# Altium schematic sheet P05_MPU4.SchDoc(P05_MPU4)
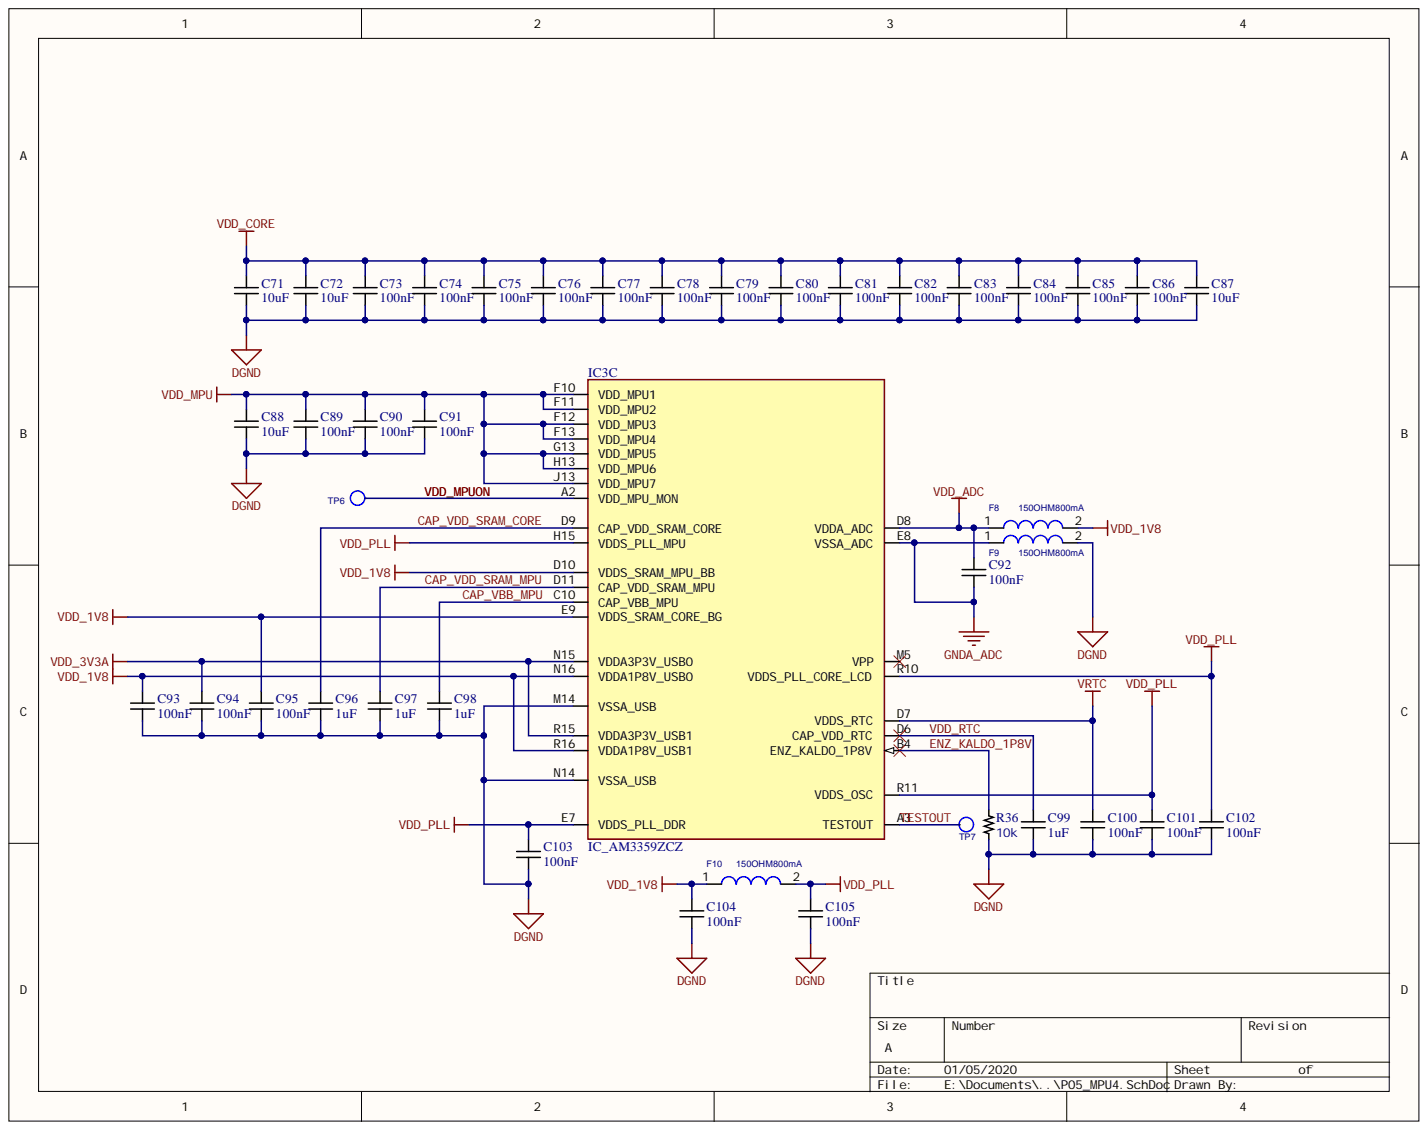
[diagram p0: P05_MPU4.SchDoc]

## Parts
C71  Capacitance: 10uF  [CAP0805]  (hidden comment: C2012X6S1A106K085AC)
C72  Capacitance: 10uF  [CAP0805]  (hidden comment: C2012X6S1A106K085AC)
C73  Capacitance: 100nF  [CAP0402]  (hidden comment: C0402C104K4RACTU)
C74  Capacitance: 100nF  [CAP0402]  (hidden comment: C0402C104K4RACTU)
C75  Capacitance: 100nF  [CAP0402]  (hidden comment: C0402C104K4RACTU)
C76  Capacitance: 100nF  [CAP0402]  (hidden comment: C0402C104K4RACTU)
C77  Capacitance: 100nF  [CAP0402]  (hidden comment: C0402C104K4RACTU)
C78  Capacitance: 100nF  [CAP0402]  (hidden comment: C0402C104K4RACTU)
C79  Capacitance: 100nF  [CAP0402]  (hidden comment: C0402C104K4RACTU)
C80  Capacitance: 100nF  [CAP0402]  (hidden comment: C0402C104K4RACTU)
C81  Capacitance: 100nF  [CAP0402]  (hidden comment: C0402C104K4RACTU)
C82  Capacitance: 100nF  [CAP0402]  (hidden comment: C0402C104K4RACTU)
C83  Capacitance: 100nF  [CAP0402]  (hidden comment: C0402C104K4RACTU)
C84  Capacitance: 100nF  [CAP0402]  (hidden comment: C0402C104K4RACTU)
C85  Capacitance: 100nF  [CAP0402]  (hidden comment: C0402C104K4RACTU)
C86  Capacitance: 100nF  [CAP0402]  (hidden comment: C0402C104K4RACTU)
C87  Capacitance: 10uF  [CAP0805]  (hidden comment: C2012X6S1A106K085AC)
C88  Capacitance: 10uF  [CAP0805]  (hidden comment: C2012X6S1A106K085AC)
C89  Capacitance: 100nF  [CAP0402]  (hidden comment: C0402C104K4RACTU)
C90  Capacitance: 100nF  [CAP0402]  (hidden comment: C0402C104K4RACTU)
C91  Capacitance: 100nF  [CAP0402]  (hidden comment: C0402C104K4RACTU)
C92  Capacitance: 100nF  [CAP0402]  (hidden comment: C0402C104K4RACTU)
C93  Capacitance: 100nF  [CAP0402]  (hidden comment: C0402C104K4RACTU)
C94  Capacitance: 100nF  [CAP0402]  (hidden comment: C0402C104K4RACTU)
C95  Capacitance: 100nF  [CAP0402]  (hidden comment: C0402C104K4RACTU)
C96  Capacitance: 1uF  [CAP0402]  (hidden comment: CC0402KRX5R6BB105)
C97  Capacitance: 1uF  [CAP0402]  (hidden comment: CC0402KRX5R6BB105)
C98  Capacitance: 1uF  [CAP0402]  (hidden comment: CC0402KRX5R6BB105)
C99  Capacitance: 1uF  [CAP0402]  (hidden comment: CC0402KRX5R6BB105)
C100  Capacitance: 100nF  [CAP0402]  (hidden comment: C0402C104K4RACTU)
C101  Capacitance: 100nF  [CAP0402]  (hidden comment: C0402C104K4RACTU)
C102  Capacitance: 100nF  [CAP0402]  (hidden comment: C0402C104K4RACTU)
C103  Capacitance: 100nF  [CAP0402]  (hidden comment: C0402C104K4RACTU)
C104  Capacitance: 100nF  [CAP0402]  (hidden comment: C0402C104K4RACTU)
C105  Capacitance: 100nF  [CAP0402]  (hidden comment: C0402C104K4RACTU)
F8  150OHM800mA  [FB0805]
F9  150OHM800mA  [FB0805]
F10  150OHM800mA  [FB0805]
IC3  IC_AM3359ZCZ  [BGA-324]  (part C)
R36  Resistance: 10k  [RES0402]  (hidden comment: RC0402FR-0710KL)
TP6  TESTPT1  [tp1-8040]  (value not drawn)  (no BOM)
TP7  TESTPT1  [tp1-8040]  (value not drawn)  (no BOM)

## Nets
DGND: C71.1 C72.1 C73.2 C74.2 C75.2 C76.2 C77.2 C78.2 C79.2 C80.2 C81.2 C82.2 C83.2 C84.2 C85.2 C86.2 C87.1 C88.1 C89.2 C90.2 C91.2 C93.2 C94.2 C95.2 C96.2 C97.2 C98.2 C99.2 C100.2 C101.2 C102.2 C103.2 C104.2 C105.2 F9.2 IC3.M14(VSSA_USB) IC3.N14(VSSA_USB) R36.2
GNDA_ADC: C92.2 F9.1 IC3.E8(VSSA_ADC)
VDD_1V8: C93.1 C95.1 C104.1 F8.2 F10.1 IC3.D10(VDDS_SRAM_MPU_BB) IC3.E9(VDDS_SRAM_CORE_BG) IC3.N16(VDDA1P8V_USB0) IC3.R16(VDDA1P8V_USB1)
VDD_3V3A: C94.1 IC3.N15(VDDA3P3V_USB0) IC3.R15(VDDA3P3V_USB1)
VDD_ADC: C92.1 F8.1 IC3.D8(VDDA_ADC)
VDD_CORE: C71.2 C72.2 C73.1 C74.1 C75.1 C76.1 C77.1 C78.1 C79.1 C80.1 C81.1 C82.1 C83.1 C84.1 C85.1 C86.1 C87.2
VDD_MPU: C88.2 C89.1 C90.1 C91.1 IC3.F10(VDD_MPU1) IC3.F11(VDD_MPU2) IC3.F12(VDD_MPU3) IC3.F13(VDD_MPU4) IC3.G13(VDD_MPU5) IC3.H13(VDD_MPU6) IC3.J13(VDD_MPU7)
VDD_PLL: C101.1 C102.1 C103.1 C105.1 F10.2 IC3.E7(VDDS_PLL_DDR) IC3.H15(VDDS_PLL_MPU) IC3.R10(VDDS_PLL_CORE_LCD) IC3.R11(VDDS_OSC)
VRTC: C100.1 IC3.D7(VDDS_RTC)
CAP_VBB_MPU: C98.1 IC3.C10(CAP_VBB_MPU)
CAP_VDD_SRAM_CORE: C96.1 IC3.D9(CAP_VDD_SRAM_CORE)
CAP_VDD_SRAM_MPU: C97.1 IC3.D11(CAP_VDD_SRAM_MPU)
ENZ_KALDO_1P8V: IC3.B4(ENZ_KALDO_1P8V) R36.1
TESTOUT: IC3.A3(TESTOUT) TP7.1
VDD_MPUON: IC3.A2(VDD_MPU_MON) TP6.1
VDD_RTC: C99.1 IC3.D6(CAP_VDD_RTC)

Not connected: IC3 M5(VPP)

## Library data of the parts
C71 C72 C87 C88 = CAP_10uF: MLCC 10uF 0805 SMD; Manufacturer: TDK; Voltage Rating (DC): 10V; Tolerance: 10%; Dielectric Material: Ceramic; Dielectric: X6S; Width: 0.049inch; Weight: 0.000194oz; Voltage Rating: 10V; Thickness: 0.037inch; Termination: SMD/SMT; Supplier Part Number 1: 445-7613-1-ND; Supplier 1: Digi-Key; Stock 1: 46852; RoHS: Yes; REACH SVHC: No SVHC; Pricing 1: 1=0.30000, 10=0.20500, 100=0.11610, 500=0.08198, 1000=0.07173 (USD); Packaging: Tape and Reel; Package Quantity: 4000; Mount: Surface Mount; Min Operating Temperature: -55°C; Max Operating Temperature: 105°C; Manufacturer Part Number: C2012X6S1A106K085AC; Length: 2mm; Lead Free: Lead Free; Height: 1.25mm; Depth: 1.25mm; Composition: Ceramic; ComponentLink3URL: https://datasheet.ciiva.com/6290/tdkmlcccaprange-6290501.pdf?src-supplier=Digi-Key; ComponentLink3Description: Datasheet; Component Kind: Standard; Case Code (Metric): 2012; Case Code (Imperial): 0805; Case/Package: 0805; Library Name: Orient.SchLib
C73-C86 C89-C95 C100-C105 = CAP_100nF: MLCC 100nF 0402 SMD; Manufacturer: KEMET; Voltage Rating (DC): 16V; Tolerance: 10%; Dissipation Factor: 3.5%; Dielectric: X7R; Width: 0.5mm; Voltage Rating: 16V; Termination: SMD/SMT; Supplier Part Number 1: 399-3521-1-ND; Supplier 1: Digi-Key; Stock 1: 6512243; RoHS: Yes; Radiation Hardening: No; Pricing 1: 1=0.10000, 10=0.04200, 100=0.01860, 500=0.01328, 1000=0.01044, 2500=0.00949, 5000=0.00873 (USD); Packaging: Tape and Reel; Package Quantity: 10000; Mount: Surface Mount; Min Operating Temperature: -55°C; Max Operating Temperature: 125°C; Manufacturer Part Number: C0402C104K4RACTU; Length: 1mm; Height: 0.5mm; Depth: 0.5mm; Contact Plating: Tin,Matte; ComponentLink2URL: https://datasheet.ciiva.com/18192/getdatasheetpartid-385384-18192412.pdf?src-supplier=Verical; ComponentLink2Description: Datasheet; Component Kind: Standard; Case Code (Metric): 1005; Case Code (Imperial): 0402; Case/Package: 0402; Library Name: Orient.SchLib
C96-C99 = CAP_1uF: MLCC 1uF 0402 SMD; Manufacturer: Yageo; Voltage Rating (DC): 10V; Tolerance: 10%; Dissipation Factor: 15%; Dielectric: X5R; Width: 0.02inch; Voltage Rating: 10V; Thickness: 0.022inch; Termination: SMD/SMT; Supplier 1: Digi-Key; Stock 1: 6411796; RoHS: Yes; REACH SVHC: No SVHC; Radiation Hardening: No; Pricing 1: 1=0.10000, 10=0.06300, 100=0.02790, 500=0.01996, 1000=0.01568, 2500=0.01425, 5000=0.01311 (USD); Packaging: Tape and Reel; Package Quantity: 1; Number of Pins: 2; Mount: Surface Mount; Min Operating Temperature: -55°C; Max Operating Temperature: 85°C; Manufacturer Part Number: CC0402KRX5R6BB105; Length: 1mm; Lead Free: Lead Free; Height: 0.5mm; Halogen Free: Halogen Free; Depth: 0.5mm; Composition: Ceramic; ComponentLink3URL: https://datasheet.ciiva.com/176/mlccorderingcode-176705.pdf?src-supplier=Digi-Key; ComponentLink3Description: https://datasheet.ciiva.com/176/mlccorderingcode-176705.pdf?src-supplier=Digi-Key; ComponentLink2URL: https://datasheet.ciiva.com/176/mlccorderingcode-176706.pdf?src-supplier=Digi-Key; ComponentLink2Description: https://datasheet.ciiva.com/176/mlccorderingcode-176706.pdf?src-supplier=Digi-Key; ComponentLink1URL: https://datasheet.ciiva.com/176/upy-gphc-x5r-4v-to-50v-12-176704.pdf?src-supplier=Digi-Key; ComponentLink1Description: https://datasheet.ciiva.com/176/upy-gphc-x5r-4v-to-50v-12-176704.pdf?src-supplier=Digi-Key; Component Kind: Standard; Case Code (Metric): 1005; Case Code (Imperial): 0402; Case/Package: 0402; Library Name: Orient.SchLib
F8-F10 = FERR: FERRITE 800MA 150 OHM 0805 SMD; TIIntPartnumber: 1L0010; PartName: FerriteBead150ohm800mA; MfrNum: LI0805H151R-10; Mfr: Steward; Manufacturer: Laird-Signal Integrity Products; Vendor: Digikey; Impedance @ Frequency: 150 Ohm @ 100MHz; Filter Type: Differential Mode - Single; DC Resistance (DCR): 150 mOhm Max; Supplier Part Number 1: 240-2384-6-ND; Supplier 1: Digi-Key; Stock 1: 3694; Size / Dimension: 0.079" L x 0.049" W (2.00mm x 1.25mm); RoHS: RoHS Compliant; Pricing 1: 1=0.1, 10=0.07, 25=0.064, 50=0.0574, 100=0.0421, 250=0.03064, 500=0.02808, 1000=0.02424 (USD); Packaging: Digi-Reel®; Package / Case: 0805 (2012 Metric); Mounting Type: Surface Mount; Manufacturer Part Number: LI0805H151R-10; Height (Max): 0.043" (1.10mm); Current Rating: 800mA; ComponentLink1URL: http://www.lairdtech.com/WorkArea/linkit.aspx?LinkIdentifier=id&ItemID=4912; ComponentLink1Description: http://www.lairdtech.com/WorkArea/linkit.aspx?LinkIdentifier=id&ItemID=4912; Component Kind: Standard; Category: Filters; Library Name: Orient.SchLib
IC3 = IC_AM335X_ZCE_1: SubArctic Cortex A8 Processor 18x18; Manufacturer Part Number: XAM3359ZCZ; Manufacturer: Texas Instruments; Voltage: 1.06 V ~ 1.15 V; Processor Type: ARM Microprocessor; Supplier Part Number 1: 296-35073-ND; Supplier Device Package: 324-NFBGA(15x15); Supplier 1: Digi-Key; Stock 1: 16; Speed: -; RoHS: RoHS Compliant; Pricing 1: 1=33.5, 10=30.988, 100=26.465, 250=24.28752, 500=23.115, 1000=21.775, 2500=21.105, 5000=20.6025, 10000=20.1 (USD); Packaging: Tray; Package / Case: 324-LFBGA; Mounting Type: Surface Mount; Features: -; ComponentLink1URL: http://www.ti.com/lit/ds/symlink/am3354.pdf; ComponentLink1Description: http://www.ti.com/lit/ds/symlink/am3354.pdf; Component Kind: Standard; Category: Integrated Circuits (ICs); Library Name: Orient.SchLib
R36 = RES_10k: Resistor 10kOhm 0402 SMD; Manufacturer: Yageo; Working Voltage: 50V; Tolerance: 1%; Temperature Coefficient: 100ppm/°C; Power Rating: 62.5mW; Width: 0.5mm; Voltage Rating (DC): 50V; Voltage Rating: 50V; Termination: SMD/SMT; Supplier 1: Digi-Key; Stock 1: 72891652; RoHS: Yes; REACH SVHC: No SVHC; Pricing 1: 1=0.10000, 10=0.01500, 100=0.00600, 1000=0.00270, 2500=0.00235, 5000=0.00194 (USD); Packaging: Tape and Reel; Number of Terminations: 2; Number of Pins: 2; Min Operating Temperature: -55°C; Military Standard: Not; Max Operating Temperature: 155°C; Manufacturer Part Number: RC0402FR-0710KL; Length: 1mm; Lead Pitch: 0.2mm; Lead Free: Lead Free; Height: 0.32mm; Depth: 0.5mm; Composition: Thick Film; ComponentLink7URL: https://datasheet.ciiva.com/12667/pyu-rc0402-51-rohs-l-6-r-12667067.pdf?src-supplier=Mouser; ComponentLink7Description: https://datasheet.ciiva.com/12667/pyu-rc0402-51-rohs-l-6-r-12667067.pdf?src-supplier=Mouser; ComponentLink6URL: https://datasheet.ciiva.com/22747/1018594-22747512.pdf?src-supplier=Element14; ComponentLink6Description: https://datasheet.ciiva.com/22747/1018594-22747512.pdf?src-supplier=Element14; ComponentLink5URL: https://datasheet.ciiva.com/7849/1784430-7849815.pdf?src-supplier=Element14; ComponentLink5Description: https://datasheet.ciiva.com/7849/1784430-7849815.pdf?src-supplier=Element14; ComponentLink4URL: https://datasheet.ciiva.com/7849/1686128-7849814.pdf?src-supplier=Element14; ComponentLink4Description: https://datasheet.ciiva.com/7849/1686128-7849814.pdf?src-supplier=Element14; ComponentLink3URL: https://datasheet.ciiva.com/7849/1784430-7849813.pdf?src-supplier=Element14; ComponentLink3Description: https://datasheet.ciiva.com/7849/1784430-7849813.pdf?src-supplier=Element14; ComponentLink2URL: https://datasheet.ciiva.com/7983/1018594-7983486.pdf?src-supplier=Element14; ComponentLink2Description: https://datasheet.ciiva.com/7983/1018594-7983486.pdf?src-supplier=Element14; ComponentLink1URL: https://datasheet.ciiva.com/7660/38568-7660787.pdf?src-supplier=Element14; ComponentLink1Description: https://datasheet.ciiva.com/7660/38568-7660787.pdf?src-supplier=Element14; Component Kind: Standard; Case Code (Metric): 1005; Case Code (Imperial): 0402; Case/Package: 0402; Library Name: Orient.SchLib
TP6 TP7 = TESTPT1: Library Name: Orient.SchLib
